# Altium schematic sheet BC26_OPEN_SIMCARD_RS232.SchDoc(BC26_OPEN_SIMCARD_RS232)
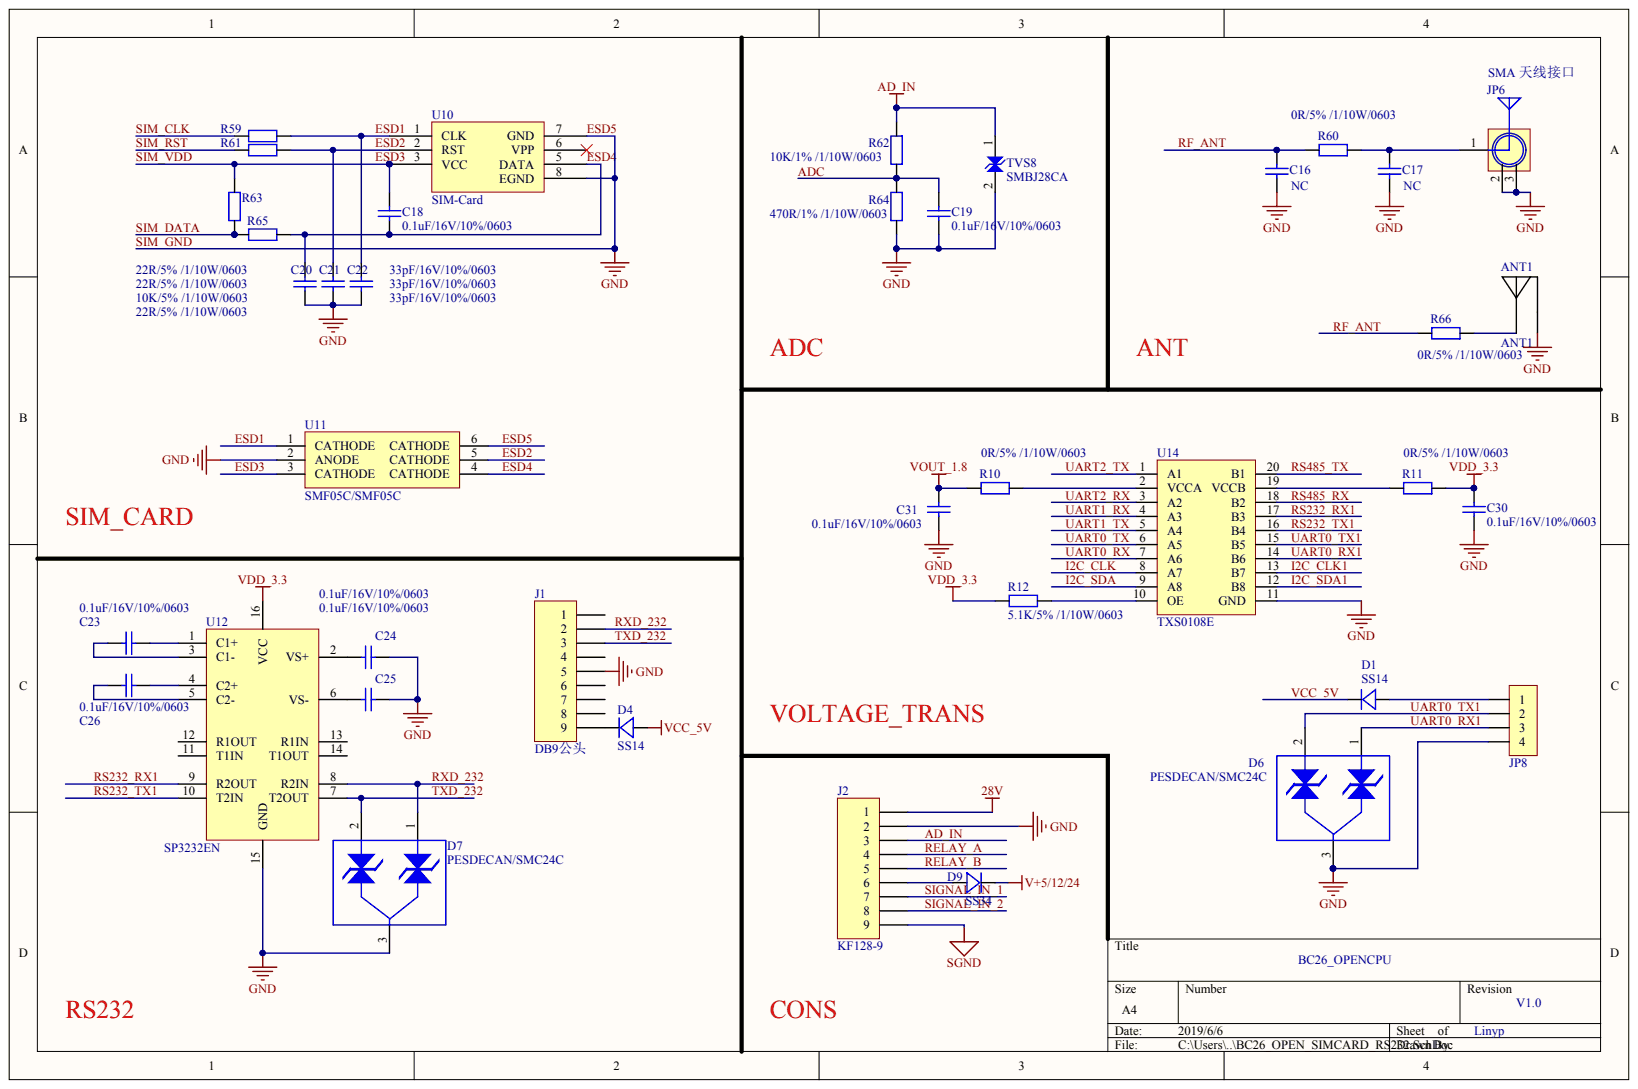
[diagram p0: BC26_OPEN_SIMCARD_RS232.SchDoc]

## Parts
ANT1  ANT1
C16  NC
C17  NC
C18  0.1uF/16V/10%/0603
C19  0.1uF/16V/10%/0603
C20  33pF/16V/10%/0603
C21  33pF/16V/10%/0603
C22  33pF/16V/10%/0603
C23  0.1uF/16V/10%/0603
C24  0.1uF/16V/10%/0603
C25  0.1uF/16V/10%/0603
C26  0.1uF/16V/10%/0603
C30  0.1uF/16V/10%/0603
C31  0.1uF/16V/10%/0603
D1  SS14
D4  SS14
D6  PESDECAN/SMC24C
D7  PESDECAN/SMC24C
D9  SS34
J1  DB9公头
J2  KF128-9
JP6  SMA 天线接口
JP8  HDR-1X4  (value not drawn)
R10  0R/5% /1/10W/0603
R11  0R/5% /1/10W/0603
R12  5.1K/5% /1/10W/0603
R59  22R/5% /1/10W/0603
R60  0R/5% /1/10W/0603
R61  22R/5% /1/10W/0603
R62  10K/1% /1/10W/0603
R63  10K/5% /1/10W/0603
R64  470R/1% /1/10W/0603
R65  22R/5% /1/10W/0603
R66  0R/5% /1/10W/0603
TVS8  SMBJ28CA
U10  SIM-Card
U11  SMF05C/SMF05C
U12  SP3232EN
U14  TXS0108E

## Nets
28V: J2.1
SGND: J2.9
V+5/12/24: D9.2
VDD_3.3: C30.2 R11.1 R12.2 U12.16(VCC)
VOUT_1.8: C31.2 R10.2
AD_IN: J2.3 R62.1 TVS8.1
ADC: C19.1 R62.2 R64.2
ESD1: C22.2 R59.2 U10.1(CLK) U11.1(CATHODE)
ESD2: C21.2 R61.2 U10.2(RST) U11.5(CATHODE)
ESD3: C18.2 R63.1 U10.3(VCC) U11.3(CATHODE)
ESD4: C18.1 C20.2 R65.1 U10.5(DATA) U11.4(CATHODE)
ESD5: ANT1.2 C16.2 C17.2 C19.2 C20.1 C21.1 C22.1 C24.2 C25.2 C30.1 C31.1 D6.3 D7.3 J1.5 J2.2 JP6.2 JP6.3 JP8.4 R64.1 TVS8.2 U10.7(GND) U10.8(EGND) U11.2(ANODE) U11.6(CATHODE) U12.15(GND) U14.11(GND)
I2C_CLK: U14.8(A7)
I2C_CLK1: U14.13(B7)
I2C_SDA: U14.9(A8)
I2C_SDA1: U14.12(B8)
RELAY_A: J2.4
RELAY_B: J2.5
RF_ANT: C16.1 R60.2 R66.2
RS232_RX1: U12.9(R2OUT) U14.17(B3)
RS232_TX1: U12.10(T2IN) U14.16(B4)
RS485_RX: U14.18(B2)
RS485_TX: U14.20(B1)
RXD_232: D7.1 J1.2 U12.8(R2IN)
SIGNAL_IN_1: J2.7
SIGNAL_IN_2: J2.8
SIM_CLK: R59.1
SIM_DATA: R63.2 R65.2
SIM_RST: R61.1
TXD_232: D7.2 J1.3 U12.7(T2OUT)
UART0_RX: U14.7(A6)
UART0_RX1: D6.1 JP8.3 U14.14(B6)
UART0_TX: U14.6(A5)
UART0_TX1: D6.2 JP8.2 U14.15(B5)
UART1_RX: U14.4(A3)
UART1_TX: U14.5(A4)
UART2_RX: U14.3(A2)
UART2_TX: U14.1(A1)
VCC_5V: D1.2 D4.1
NetANT1_1: ANT1.1 R66.1
NetC17_1: C17.1 JP6.1 R60.1
NetC23_1: C23.1 U12.3(C1-)
NetC23_2: C23.2 U12.1(C1+)
NetC24_1: C24.1 U12.2(VS+)
NetC25_1: C25.1 U12.6(VS-)
NetC26_1: C26.1 U12.5(C2-)
NetC26_2: C26.2 U12.4(C2+)
NetD1_1: D1.1 JP8.1
NetD4_2: D4.2 J1.9
NetD9_1: D9.1 J2.6
NetR10_1: R10.1 U14.2(VCCA)
NetR11_2: R11.2 U14.19(VCCB)
NetR12_1: R12.1 U14.10(OE)

Not connected: J1 1 4 6 7 8; U10 6(VPP); U12 11(T1IN) 12(R1OUT) 13(R1IN) 14(T1OUT)

## Library data of the parts
ANT1 = (no symbol name): BOM名称: PCB板载天线; 版权归属: 源创客; 学习资源: www.ooocke.com
JP6 = (no symbol name): BOM名称: SMA-2.4G射频天线接口; 版权归属: 源创客; 学习资源: www.ooocke.com
JP8 = (no symbol name): BOM名称: 4P接插件; 版权归属: 源创客; 学习资源: www.ooocke.com
C16-C26 C30 C31 D1 D4 D6 D7 D9 J1 J2 R10-R12 R59-R66 TVS8 U10-U12 U14 = (no symbol name)
  C16-C26 C30 C31: Published: 8-Jun-2000; LatestRevisionDate: 17-Jul-2002; LatestRevisionNote: Re-released for DXP Platform.; PackageReference: RAD-0.3
  J1 J2: LatestRevisionDate: 17-Jul-2002; LatestRevisionNote: Re-released for DXP Platform.
  C16-C26 C30 C31 J1 J2: Publisher: Altium Limited
